# Altium schematic sheet 02-Power-Sequencing.SchDoc(Power-Sequencing)
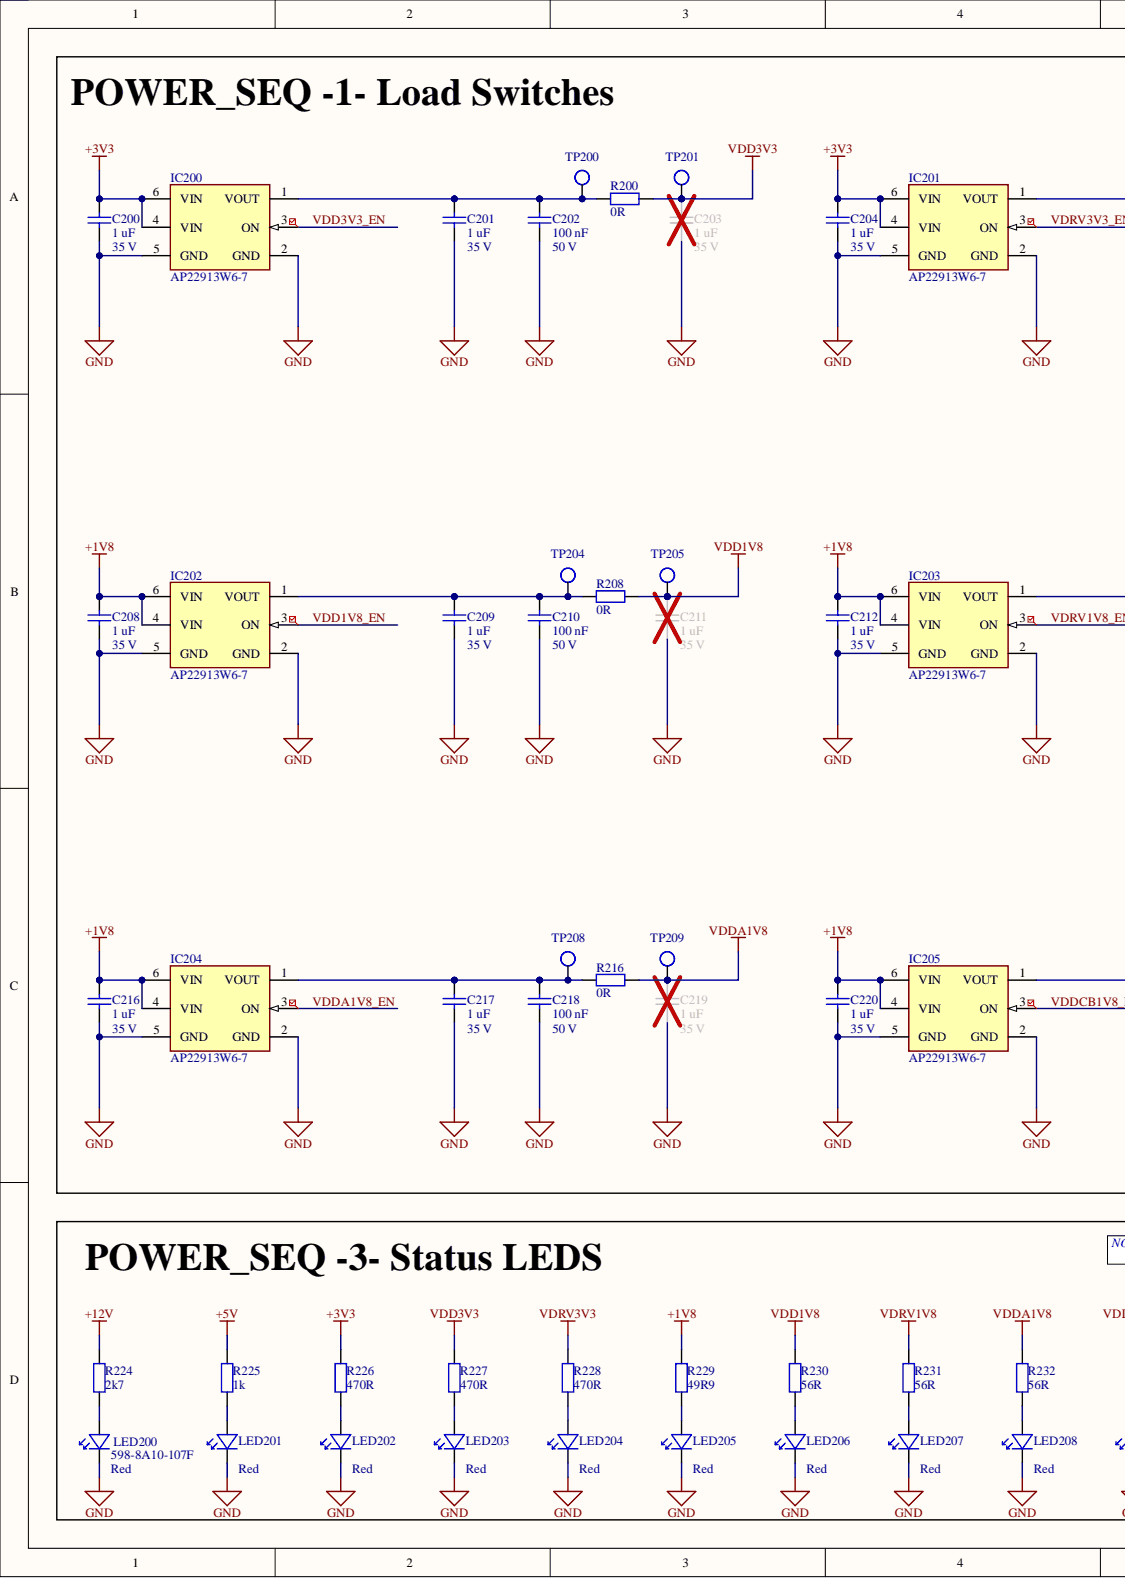
[diagram p0: 02-Power-Sequencing.SchDoc, left]
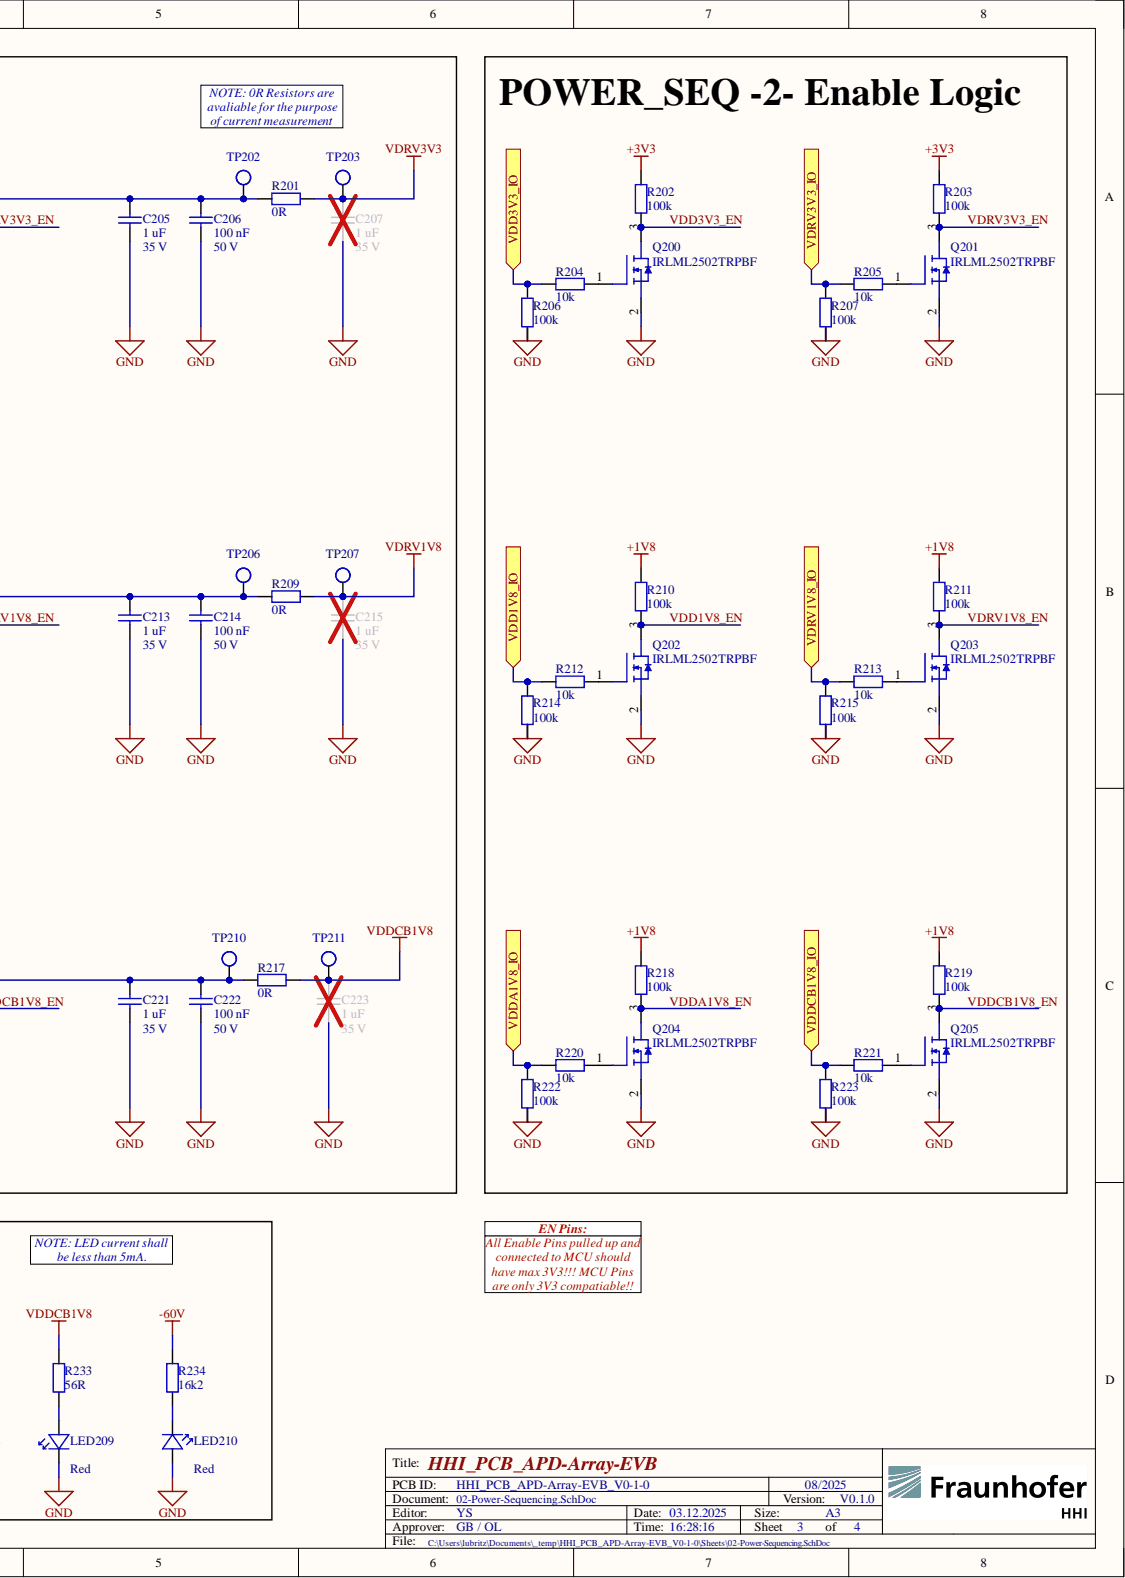
[diagram p1: 02-Power-Sequencing.SchDoc, right]

## Parts
C200  1 uF  [CAP_CAPC1608_0603_N]
C201  1 uF  Voltage: 35 V  [CAP_CAPC1608_0603_N]
C202  100 nF  Voltage: 50 V  [CAP_CAPC1608_0603_N]
C203  1 uF  Voltage: 35 V  [CAP_CAPC1608_0603_N]
C204  1 uF  [CAP_CAPC1608_0603_N]
C205  1 uF  Voltage: 35 V  [CAP_CAPC1608_0603_N]
C206  100 nF  Voltage: 50 V  [CAP_CAPC1608_0603_N]
C207  1 uF  Voltage: 35 V  [CAP_CAPC1608_0603_N]
C208  1 uF  [CAP_CAPC1608_0603_N]
C209  1 uF  Voltage: 35 V  [CAP_CAPC1608_0603_N]
C210  100 nF  Voltage: 50 V  [CAP_CAPC1608_0603_N]
C211  1 uF  Voltage: 35 V  [CAP_CAPC1608_0603_N]
C212  1 uF  [CAP_CAPC1608_0603_N]
C213  1 uF  Voltage: 35 V  [CAP_CAPC1608_0603_N]
C214  100 nF  Voltage: 50 V  [CAP_CAPC1608_0603_N]
C215  1 uF  Voltage: 35 V  [CAP_CAPC1608_0603_N]
C216  1 uF  [CAP_CAPC1608_0603_N]
C217  1 uF  Voltage: 35 V  [CAP_CAPC1608_0603_N]
C218  100 nF  Voltage: 50 V  [CAP_CAPC1608_0603_N]
C219  1 uF  Voltage: 35 V  [CAP_CAPC1608_0603_N]
C220  1 uF  [CAP_CAPC1608_0603_N]
C221  1 uF  Voltage: 35 V  [CAP_CAPC1608_0603_N]
C222  100 nF  Voltage: 50 V  [CAP_CAPC1608_0603_N]
C223  1 uF  Voltage: 35 V  [CAP_CAPC1608_0603_N]
IC200  AP22913W6-7  [IC_SOT26-6_SOT95P285X130-6N]
IC201  AP22913W6-7  [IC_SOT26-6_SOT95P285X130-6N]
IC202  AP22913W6-7  [IC_SOT26-6_SOT95P285X130-6N]
IC203  AP22913W6-7  [IC_SOT26-6_SOT95P285X130-6N]
IC204  AP22913W6-7  [IC_SOT26-6_SOT95P285X130-6N]
IC205  AP22913W6-7  [IC_SOT26-6_SOT95P285X130-6N]
LED200  598-8A10-107F  Color: Red  [OPTO_5988A10107F]
LED201  598-8A10-107F  Color: Red  [OPTO_5988A10107F]
LED202  598-8A10-107F  Color: Red  [OPTO_5988A10107F]
LED203  598-8A10-107F  Color: Red  [OPTO_5988A10107F]
LED204  598-8A10-107F  Color: Red  [OPTO_5988A10107F]
LED205  598-8A10-107F  Color: Red  [OPTO_5988A10107F]
LED206  598-8A10-107F  Color: Red  [OPTO_5988A10107F]
LED207  598-8A10-107F  Color: Red  [OPTO_5988A10107F]
LED208  598-8A10-107F  Color: Red  [OPTO_5988A10107F]
LED209  598-8A10-107F  Color: Red  [OPTO_5988A10107F]
LED210  598-8A10-107F  Color: Red  [OPTO_5988A10107F]
Q200  IRLML2502TRPBF  [TRANS_SOT23-3_N]
Q201  IRLML2502TRPBF  [TRANS_SOT23-3_N]
Q202  IRLML2502TRPBF  [TRANS_SOT23-3_N]
Q203  IRLML2502TRPBF  [TRANS_SOT23-3_N]
Q204  IRLML2502TRPBF  [TRANS_SOT23-3_N]
Q205  IRLML2502TRPBF  [TRANS_SOT23-3_N]
R200  0R  [RES_RESC1608_0603_N]
R201  0R  [RES_RESC1608_0603_N]
R202  100k  [RES_RESC1608_0603_N]
R203  100k  [RES_RESC1608_0603_N]
R204  10k  [RES_RESC1608_0603_N]
R205  10k  [RES_RESC1608_0603_N]
R206  100k  [RES_RESC1608_0603_N]
R207  100k  [RES_RESC1608_0603_N]
R208  0R  [RES_RESC1608_0603_N]
R209  0R  [RES_RESC1608_0603_N]
R210  100k  [RES_RESC1608_0603_N]
R211  100k  [RES_RESC1608_0603_N]
R212  10k  [RES_RESC1608_0603_N]
R213  10k  [RES_RESC1608_0603_N]
R214  100k  [RES_RESC1608_0603_N]
R215  100k  [RES_RESC1608_0603_N]
R216  0R  [RES_RESC1608_0603_N]
R217  0R  [RES_RESC1608_0603_N]
R218  100k  [RES_RESC1608_0603_N]
R219  100k  [RES_RESC1608_0603_N]
R220  10k  [RES_RESC1608_0603_N]
R221  10k  [RES_RESC1608_0603_N]
R222  100k  [RES_RESC1608_0603_N]
R223  100k  [RES_RESC1608_0603_N]
R224  2k7  [RES_RESC1608_0603_N]
R225  1k  [RES_RESC1608_0603_N]
R226  470R  [RES_RESC1608_0603_N]
R227  470R  [RES_RESC1608_0603_N]
R228  470R  [RES_RESC1608_0603_N]
R229  49R9  [RES_RESC1608_0603_N]
R230  56R  [RES_RESC1608_0603_N]
R231  56R  [RES_RESC1608_0603_N]
R232  56R  [RES_RESC1608_0603_N]
R233  56R  [RES_RESC1608_0603_N]
R234  16k2  [RES_RESC1608_0603_N]
TP200  0,8 mm / 1,4 mm  [MISC_TP_THT_RD_0,8MM_1,4MM]  (value not drawn)  (no BOM)
TP201  0,8 mm / 1,4 mm  [MISC_TP_THT_RD_0,8MM_1,4MM]  (value not drawn)  (no BOM)
TP202  0,8 mm / 1,4 mm  [MISC_TP_THT_RD_0,8MM_1,4MM]  (value not drawn)  (no BOM)
TP203  0,8 mm / 1,4 mm  [MISC_TP_THT_RD_0,8MM_1,4MM]  (value not drawn)  (no BOM)
TP204  0,8 mm / 1,4 mm  [MISC_TP_THT_RD_0,8MM_1,4MM]  (value not drawn)  (no BOM)
TP205  0,8 mm / 1,4 mm  [MISC_TP_THT_RD_0,8MM_1,4MM]  (value not drawn)  (no BOM)
TP206  0,8 mm / 1,4 mm  [MISC_TP_THT_RD_0,8MM_1,4MM]  (value not drawn)  (no BOM)
TP207  0,8 mm / 1,4 mm  [MISC_TP_THT_RD_0,8MM_1,4MM]  (value not drawn)  (no BOM)
TP208  0,8 mm / 1,4 mm  [MISC_TP_THT_RD_0,8MM_1,4MM]  (value not drawn)  (no BOM)
TP209  0,8 mm / 1,4 mm  [MISC_TP_THT_RD_0,8MM_1,4MM]  (value not drawn)  (no BOM)
TP210  0,8 mm / 1,4 mm  [MISC_TP_THT_RD_0,8MM_1,4MM]  (value not drawn)  (no BOM)
TP211  0,8 mm / 1,4 mm  [MISC_TP_THT_RD_0,8MM_1,4MM]  (value not drawn)  (no BOM)

## Ports
VDD1V8_IO, VDD3V3_IO, VDDA1V8_IO, VDDCB1V8_IO, VDRV1V8_IO, VDRV3V3_IO

## Nets
+1V8: C208.1 C212.1 C216.1 C220.1 IC202.4(VIN) IC202.6(VIN) IC203.4(VIN) IC203.6(VIN) IC204.4(VIN) IC204.6(VIN) IC205.4(VIN) IC205.6(VIN) R210.2 R211.2 R218.2 R219.2 R229.2
+3V3: C200.1 C204.1 IC200.4(VIN) IC200.6(VIN) IC201.4(VIN) IC201.6(VIN) R202.2 R203.2 R226.2
+5V: R225.2
+12V: R224.2
-60V: R234.2
GND: C200.2 C201.2 C202.2 C203.2 C204.2 C205.2 C206.2 C207.2 C208.2 C209.2 C210.2 C211.2 C212.2 C213.2 C214.2 C215.2 C216.2 C217.2 C218.2 C219.2 C220.2 C221.2 C222.2 C223.2 IC200.2(GND) IC200.5(GND) IC201.2(GND) IC201.5(GND) IC202.2(GND) IC202.5(GND) IC203.2(GND) IC203.5(GND) IC204.2(GND) IC204.5(GND) IC205.2(GND) IC205.5(GND) LED200.1 LED201.1 LED202.1 LED203.1 LED204.1 LED205.1 LED206.1 LED207.1 LED208.1 LED209.1 LED210.2 Q200.2 Q201.2 Q202.2 Q203.2 Q204.2 Q205.2 R206.1 R207.1 R214.1 R215.1 R222.1 R223.1
VDD1V8: C211.1 R208.1 R230.2 TP205.1
VDD3V3: C203.1 R200.1 R227.2 TP201.1
VDDA1V8: C219.1 R216.1 R232.2 TP209.1
VDDCB1V8: C223.1 R217.1 R233.2 TP211.1
VDRV1V8: C215.1 R209.1 R231.2 TP207.1
VDRV3V3: C207.1 R201.1 R228.2 TP203.1
VDD1V8_EN: IC202.3(ON) Q202.3 R210.1
VDD1V8_IO: R212.2 R214.2 ; port VDD1V8_IO
VDD3V3_EN: IC200.3(ON) Q200.3 R202.1
VDD3V3_IO: R204.2 R206.2 ; port VDD3V3_IO
VDDA1V8_EN: IC204.3(ON) Q204.3 R218.1
VDDA1V8_IO: R220.2 R222.2 ; port VDDA1V8_IO
VDDCB1V8_EN: IC205.3(ON) Q205.3 R219.1
VDDCB1V8_IO: R221.2 R223.2 ; port VDDCB1V8_IO
VDRV1V8_EN: IC203.3(ON) Q203.3 R211.1
VDRV1V8_IO: R213.2 R215.2 ; port VDRV1V8_IO
VDRV3V3_EN: IC201.3(ON) Q201.3 R203.1
VDRV3V3_IO: R205.2 R207.2 ; port VDRV3V3_IO
NetC201_1: C201.1 C202.1 IC200.1(VOUT) R200.2 TP200.1
NetC205_1: C205.1 C206.1 IC201.1(VOUT) R201.2 TP202.1
NetC209_1: C209.1 C210.1 IC202.1(VOUT) R208.2 TP204.1
NetC213_1: C213.1 C214.1 IC203.1(VOUT) R209.2 TP206.1
NetC217_1: C217.1 C218.1 IC204.1(VOUT) R216.2 TP208.1
NetC221_1: C221.1 C222.1 IC205.1(VOUT) R217.2 TP210.1
NetLED200_2: LED200.2 R224.1
NetLED201_2: LED201.2 R225.1
NetLED202_2: LED202.2 R226.1
NetLED203_2: LED203.2 R227.1
NetLED204_2: LED204.2 R228.1
NetLED205_2: LED205.2 R229.1
NetLED206_2: LED206.2 R230.1
NetLED207_2: LED207.2 R231.1
NetLED208_2: LED208.2 R232.1
NetLED209_2: LED209.2 R233.1
NetLED210_1: LED210.1 R234.1
NetQ200_1: Q200.1 R204.1
NetQ201_1: Q201.1 R205.1
NetQ202_1: Q202.1 R212.1
NetQ203_1: Q203.1 R213.1
NetQ204_1: Q204.1 R220.1
NetQ205_1: Q205.1 R221.1

## Library data of the parts
C200 C204 C208 C212 C216 C220 = CAP0029: CAP / 1 uF / 0603 / 35 V / X7R / 10%; Mfr: TDK; MfrNo: C1608X7R1V105K080AE; Database Table Name: capacitor$; Dis: Mouser; DisNo: 810-C1608X7R1V105KAE; Editor: OL; ProvidedBy: EMS; Type: MLCC; Voltage: 35 V; Component Kind: Standard; DesignItemID: CAP0029; Dielectric: X7R; ESR: -; Mfr-Link: https://product.tdk.com/de/search/capacitor/ceramic/mlcc/info?part_no=C1608X7R1V105K080AE; Package: 0603; Pins: 2; Status: Done; TempHigh: 125 °C; TempLow: -55 °C; Tolerance: 10%; Value: 1 uF; Library Name: Altium-Lib-HHI.DbLib
C201 C203 C205 C207 C209 C211 C213 C215 C217 C219 C221 C223 = CAP0029: CAP / 1 uF / 0603 / 35 V / X7R / 10%; Mfr: TDK; MfrNo: C1608X7R1V105K080AE; Database Table Name: capacitor$; Dis: Mouser; DisNo: 810-C1608X7R1V105KAE; Editor: OL; ProvidedBy: EMS; Type: MLCC; Component Kind: Standard; DesignItemID: CAP0029; Dielectric: X7R; ESR: -; Mfr-Link: https://product.tdk.com/de/search/capacitor/ceramic/mlcc/info?part_no=C1608X7R1V105K080AE; Package: 0603; Pins: 2; Status: Done; TempHigh: 125 °C; TempLow: -55 °C; Tolerance: 10%; Value: 1 uF; Library Name: Altium-Lib-HHI.DbLib
C202 C206 C210 C214 C218 C222 = CAP0001: CAP / 100 nF / 0603 / 50 V / X7R / 10%; Mfr: Würth Elektronik; MfrNo: 885012206095; Database Table Name: capacitor$; Dis: Mouser; DisNo: 710-885012206095; Editor: OL; ProvidedBy: EMS; Type: MLCC; Component Kind: Standard; DesignItemID: CAP0001; Dielectric: X7R; ESR: -; Mfr-Link: https://www.we-online.com/de/components/products/WCAP-CSGP-50VDC; Package: 0603; Pins: 2; Status: Done; TempHigh: 125 °C; TempLow: -55 °C; Tolerance: 10%; Value: 100 nF; Library Name: Altium-Lib-HHI.DbLib
IC200-IC205 = IC0029: IC / power / AP22913W6-7 / Load Switch; Mfr: Diodes Incorporated; Database Table Name: ic$; Dis: Mouser; DisNo: 621-AP22913W6-7; Editor: OL; InputVoltage: 1,4 - 5,5 V; MaxCurrent: 2 A; OutputVoltage: 1,4 V - 5,5 V; ProvidedBy: EMS; AdditionalDescription: Load Switch; Component Kind: Standard; DesignItemID: IC0029; Frequency: -; Memory: -; Mfr-Link: https://www.diodes.com/part/view/AP22913; MfrNo: AP22913W6-7; Package: SOT26-6; Pins: 6; SensorType: -; Status: Done; Supply Voltage: -; TempHigh: 85  °C; TempLow: -40  °C; Type: power; Value: AP22913W6-7; Library Name: Altium-Lib-HHI.DbLib
LED200-LED210 = OPTO0016: OPTO / LED / 598-8A10-107F / 635 nm / 1,7 V / 20 mA / Red; Mfr: Dialight; Database Table Name: opto$; Dis: Mouser; DisNo: 645-5988A10107F; Editor: GB; MaxCurrent: 20 mA; ProvidedBy: EMS; Voltage: 1,7 V; Wavelength: 635 nm; Component Kind: Standard; DesignItemID: OPTO0016; Mfr-Link: https://www.dialightsignalsandcomponents.com/598-a-smd-led-0402-pkg-red-635nm-low-current-5ma-7-reel/; MfrNo: 598-8A10-107F; Package: 0402; Pins: 2; Status: Done; TempHigh: 85 °C; TempLow: -40 °C; Type: LED; Value: 598-8A10-107F; Library Name: Altium-Lib-HHI.DbLib
Q200-Q205 = TRANS0005: TRANS / NFET / IRLML2502TRPBF / 20 V / 3,4 A /; Mfr: Infineon; Database Table Name: transistor$; Dis: Mouser; DisNo: 942-IRLML2502TRPBF; Editor: OL; Ic_Id: 3,4 A; ProvidedBy: EMS; Rds(on): 80mR; Vds_ Vce: 20 V; Vgs_ Vbe: 1,2 V; Component Kind: Standard; DesignItemID: TRANS0005; Gain: -; Mfr-Link: https://www.infineon.com/cms/en/product/power/mosfet/n-channel/irlml2502/; MfrNo: IRLML2502TRPBF; Package: SOT23-3; Pins: 3; Status: Done; TempHigh: 150 °C; TempLow: -55 °C; Type: NFET; Value: IRLML2502TRPBF; Library Name: Altium-Lib-HHI.DbLib
R200 R201 R208 R209 R216 R217 = RES0072: RES / 0R / 0603 / < 20mR / 75 V / 210 mW; Mfr: Vishay; MfrNo: MCT0603MZ0000ZP500; Database Table Name: resistor$; Dis: Mouser; DisNo: 594-MCT0603MZ0000ZP5; Editor: OL; Power: 210 mW; ProvidedBy: EMS; Tolerance: < 20mR; Voltage: 75 V; Component Kind: Standard; DesignItemID: RES0072; Mfr-Link: https://www.vishay.com/en/product/28952/; Package: 0603; Pins: 2; Status: Done; TempHigh: 175 °C; TempLow: -55 °C; Type: jumper; Value: 0R; Library Name: Altium-Lib-HHI.DbLib
R202 R203 R206 R207 R210 R211 R214 R215 R218 R219 R222 R223 = RES0008: RES / 100k / 0603 / 1% / 75 V / 100 mW; Mfr: YAGEO; MfrNo: RC0603FR-07100KL; Database Table Name: resistor$; Dis: Mouser; DisNo: 603-RC0603FR-07100KL; Editor: OL; Power: 100 mW; ProvidedBy: EMS; Voltage: 75 V; Component Kind: Standard; DesignItemID: RES0008; Mfr-Link: https://www.yageo.com/upload/media/product/productsearch/datasheet/rchip/PYu-RC_Group_51_RoHS_L_12.pdf; Package: 0603; Pins: 2; Status: Done; TempHigh: 155 °C; TempLow: -55 °C; Tolerance: 1%; Type: resistor; Value: 100k; Library Name: Altium-Lib-HHI.DbLib
R204 R205 R212 R213 R220 R221 = RES0011: RES / 10k / 0603 / 1% / 75 V / 100 mW; Mfr: YAGEO; MfrNo: RC0603FR-0710KL; Database Table Name: resistor$; Dis: Mouser; DisNo: 603-RC0603FR-0710KL; Editor: OL; Power: 100 mW; ProvidedBy: EMS; Voltage: 75 V; Component Kind: Standard; DesignItemID: RES0011; Mfr-Link: https://www.yageo.com/upload/media/product/productsearch/datasheet/rchip/PYu-RC_Group_51_RoHS_L_12.pdf; Package: 0603; Pins: 2; Status: Done; TempHigh: 155 °C; TempLow: -55 °C; Tolerance: 1%; Type: resistor; Value: 10k; Library Name: Altium-Lib-HHI.DbLib
R224 = RES0127: RES / 2k7 / 0603 / 1% / 75 V / 100 mW; Mfr: YAGEO; MfrNo: RC0603FR-072K7L; Database Table Name: resistor$; Dis: Mouser; DisNo: 603-RC0603FR-072K7L; Editor: OL; Power: 100 mW; ProvidedBy: EMS; Voltage: 75 V; Component Kind: Standard; DesignItemID: RES0127; Mfr-Link: https://www.yageo.com/upload/media/product/productsearch/datasheet/rchip/PYu-RC_51_RoHS_P_6.pdf; Package: 0603; Pins: 2; Status: Done; TempHigh: 155 °C; TempLow: -55 °C; Tolerance: 1%; Type: resistor; Value: 2k7; Library Name: Altium-Lib-HHI.DbLib
R225 = RES0023: RES / 1k / 0603 / 1% / 75 V / 100 mW; Mfr: YAGEO; MfrNo: RC0603FR-071KL; Database Table Name: resistor$; Dis: Mouser; DisNo: 603-RC0603FR-071KL; Editor: OL; Power: 100 mW; ProvidedBy: EMS; Voltage: 75 V; Component Kind: Standard; DesignItemID: RES0023; Mfr-Link: https://www.yageo.com/upload/media/product/productsearch/datasheet/rchip/PYu-RC_Group_51_RoHS_L_12.pdf; Package: 0603; Pins: 2; Status: Done; TempHigh: 155 °C; TempLow: -55 °C; Tolerance: 1%; Type: resistor; Value: 1k; Library Name: Altium-Lib-HHI.DbLib
R226-R228 = RES0090: RES / 470R / 0603 / 1% / 75 V / 100 mW; Mfr: YAGEO; MfrNo: RC0603FR-07470RL; Database Table Name: resistor$; Dis: Mouser; DisNo: 603-RC0603FR-07470RL; Editor: OL; Power: 100 mW; ProvidedBy: EMS; Voltage: 75 V; Component Kind: Standard; DesignItemID: RES0090; Mfr-Link: https://www.yageo.com/upload/media/product/productsearch/datasheet/rchip/PYu-RC_Group_51_RoHS_L_12.pdf; Package: 0603; Pins: 2; Status: Done; TempHigh: 155 °C; TempLow: -55 °C; Tolerance: 1%; Type: resistor; Value: 470R; Library Name: Altium-Lib-HHI.DbLib
R229 = RES0078: RES / 49R9 / 0603 / 1% / 75 V / 100 mW; Mfr: Bourns; MfrNo: CR0603-FX-49R9ELF; Database Table Name: resistor$; Dis: Mouser; DisNo: 652-CR0603FX-49R9ELF; Editor: OL; Power: 100 mW; ProvidedBy: EMS; Voltage: 75 V; Component Kind: Standard; DesignItemID: RES0078; Mfr-Link: https://www.bourns.com/products/resistors/thick-film-chip-resistors/product/CR0603; Package: 0603; Pins: 2; Status: Done; TempHigh: 155 °C; TempLow: -55 °C; Tolerance: 1%; Type: resistor; Value: 49R9; Library Name: Altium-Lib-HHI.DbLib
R230-R233 = RES0066: RES / 56R / 0603 / 1% / 75 V / 100 mW; Mfr: YAGEO; MfrNo: RC0603FR-0756RL; Database Table Name: resistor$; Dis: Mouser; DisNo: 603-RC0603FR-0756RL; Editor: OL; Power: 100 mW; ProvidedBy: EMS; Voltage: 75 V; Component Kind: Standard; DesignItemID: RES0066; Mfr-Link: https://www.yageo.com/upload/media/product/productsearch/datasheet/rchip/PYu-RC_Group_51_RoHS_L_12.pdf; Package: 0603; Pins: 2; Status: Done; TempHigh: 155 °C; TempLow: -55 °C; Tolerance: 1%; Type: resistor; Value: 56R; Library Name: Altium-Lib-HHI.DbLib
R234 = RES0158: RES / 16k2 / 0603 / 1% / 75 V / 333 mW; Mfr: Vishay; MfrNo: CRCW060316K2FKEAHP; Database Table Name: resistor$; Dis: Mouser; DisNo: 71-CRCW060316K2FKEAH; Editor: YS; Power: 333 mW; ProvidedBy: EMS; Voltage: 75 V; Component Kind: Standard; DesignItemID: RES0158; Mfr-Link: https://www.vishay.com/en/product/20043/; Package: 0603; Pins: 2; Status: Done; TempHigh: 155 °C; TempLow: -55 °C; Tolerance: 1%; Type: resistor; Value: 16k2; Library Name: Altium-Lib-HHI.DbLib
TP200-TP211 = MISC0008: MISC / test-point / 0,8 mm / 1,4 mm / round / THT; Database Table Name: misc$; Editor: OL; AdditionalDescription: round / THT; DesignItemID: MISC0008; Dis: -; DisNo: -; Frequency: -; MaxCurrent: -; Mfr: -; Mfr-Link: -; MfrNo: -; Package: -; Pins: -; ProvidedBy: -; Status: Done; TempHigh: -; TempLow: -; Type: test-point; Value: 0,8 mm / 1,4 mm; Voltage: -; Library Name: Altium-Lib-HHI.DbLib
